# Altium schematic sheet Time_Clock_Colon_V1.0.0.SchDoc
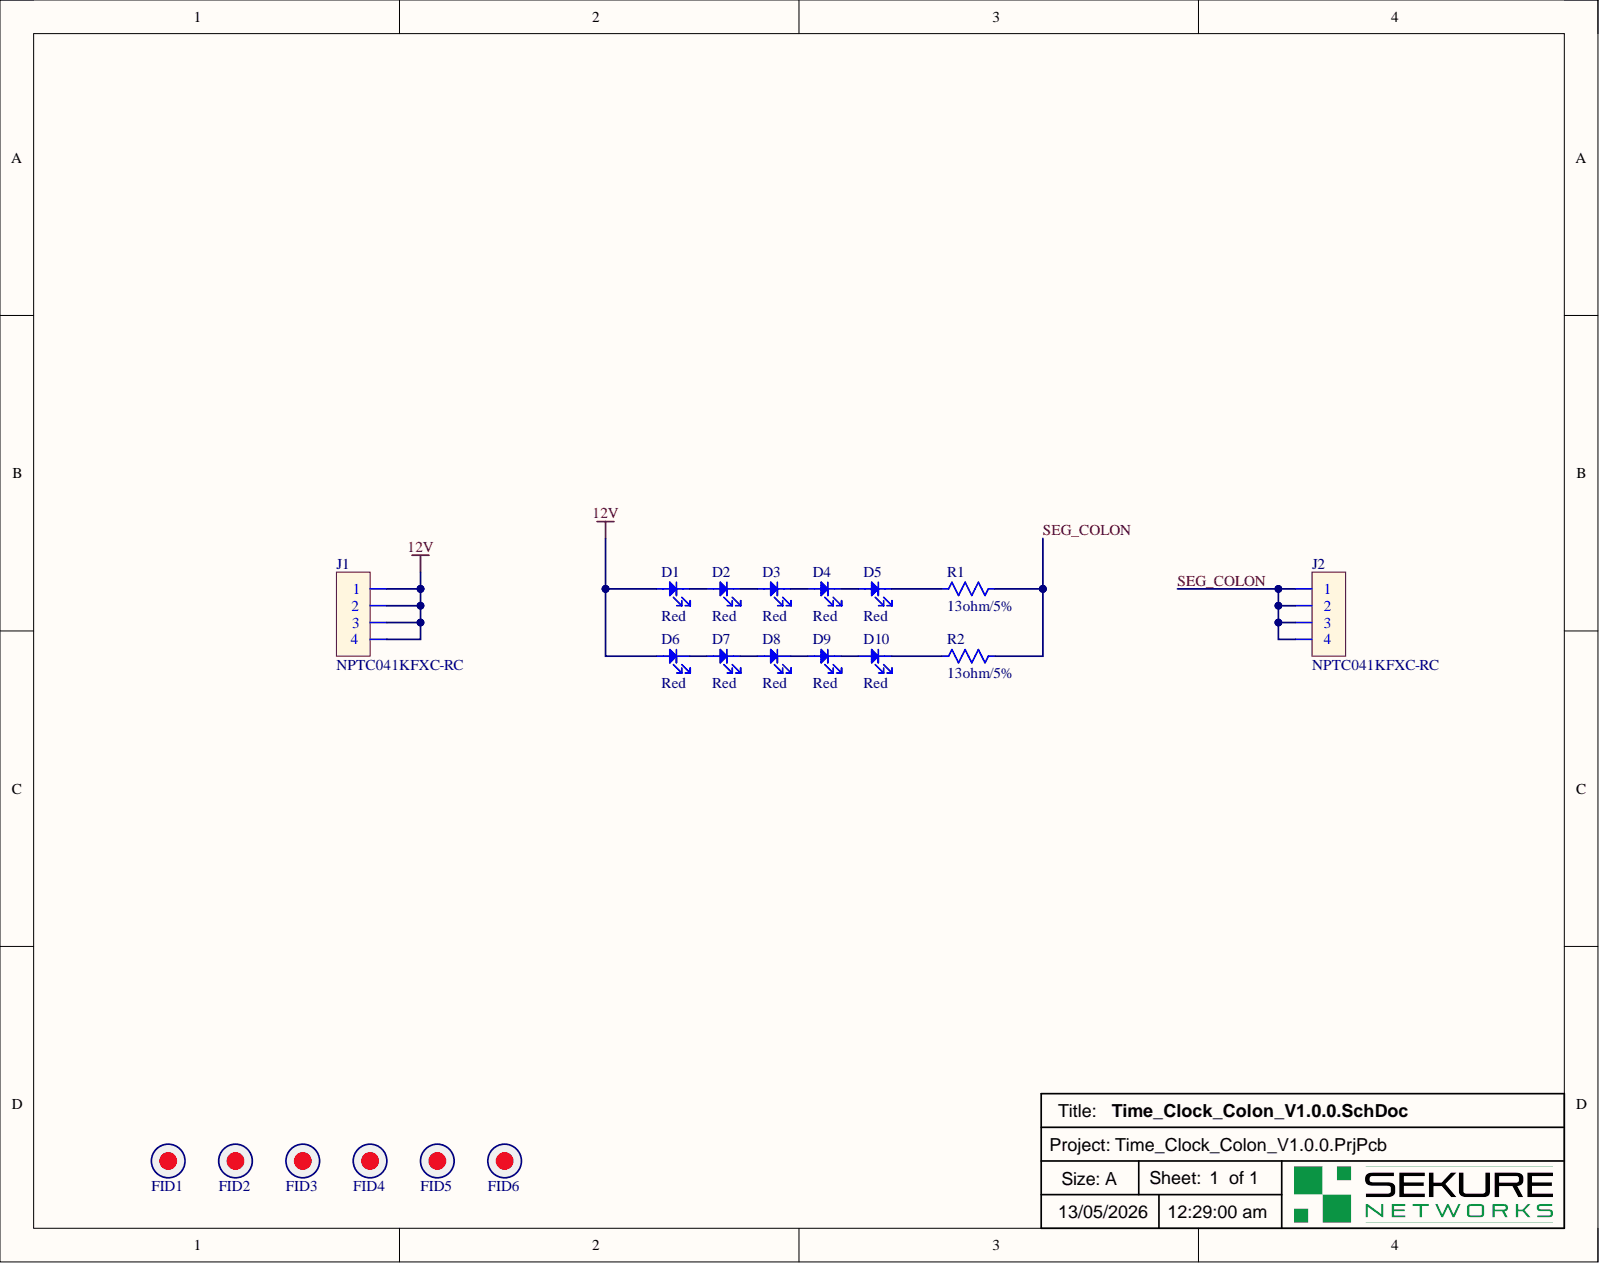
[diagram p0: Time_Clock_Colon_V1.0.0.SchDoc]

## Parts
D1  value Red  Color: Red  [1113 (2835 Metric)]  (hidden comment: LED)
D2  value Red  Color: Red  [1113 (2835 Metric)]  (hidden comment: LED)
D3  value Red  Color: Red  [1113 (2835 Metric)]  (hidden comment: LED)
D4  value Red  Color: Red  [1113 (2835 Metric)]  (hidden comment: LED)
D5  value Red  Color: Red  [1113 (2835 Metric)]  (hidden comment: LED)
D6  value Red  Color: Red  [1113 (2835 Metric)]  (hidden comment: LED)
D7  value Red  Color: Red  [1113 (2835 Metric)]  (hidden comment: LED)
D8  value Red  Color: Red  [1113 (2835 Metric)]  (hidden comment: LED)
D9  value Red  Color: Red  [1113 (2835 Metric)]  (hidden comment: LED)
D10  value Red  Color: Red  [1113 (2835 Metric)]  (hidden comment: LED)
FID1  Fiducal marks  [Fiducial mark]  (value not drawn)  (no BOM)
FID2  Fiducal marks  [Fiducial mark]  (value not drawn)  (no BOM)
FID3  Fiducal marks  [Fiducial mark]  (value not drawn)  (no BOM)
FID4  Fiducal marks  [Fiducial mark]  (value not drawn)  (no BOM)
FID5  Fiducal marks  [Fiducial mark]  (value not drawn)  (no BOM)
FID6  Fiducal marks  [Fiducial mark]  (value not drawn)  (no BOM)
J1  value NPTC041KFXC-RC  [NPTC041KFXC-RC]  (hidden comment: Connector)
J2  value NPTC041KFXC-RC  [NPTC041KFXC-RC]  (hidden comment: Connector)
R1  value 13ohm/5%  [RES 0805]  (hidden comment: Resistor)
R2  value 13ohm/5%  [RES 0805]  (hidden comment: Resistor)

## Nets
12V: D1.1 D6.1 J1.1 J1.2 J1.3 J1.4
SEG_COLON: J2.1 J2.2 J2.3 J2.4 R1.2 R2.2
NetD1_2: D1.2 D2.1
NetD2_2: D2.2 D3.1
NetD3_2: D3.2 D4.1
NetD4_2: D4.2 D5.1
NetD5_2: D5.2 R1.1
NetD6_2: D6.2 D7.1
NetD7_2: D7.2 D8.1
NetD8_2: D8.2 D9.1
NetD9_2: D9.2 D10.1
NetD10_2: D10.2 R2.1

Not connected: FID1 0; FID2 0; FID3 0; FID4 0; FID5 0; FID6 0

## Library data of the parts
D1-D10 = L128-RED1003500000: 2835 Color Line Red 17 Lumens 250 mA 2.1 V Surface Mount Mid Power LED; Manufacturer: Lumileds; Forward Current: 250mA; Forward Voltage: 2.5V; Number of Circuits: 1; Viewing Angle: 130°; Category: LEDs; Component Kind: Standard; ComponentLink1Description: https://datasheet.octopart.com:443/L128-RED1003500000-Lumileds-datasheet-180614725.pdf?src-supplier=IHS; ComponentLink1URL: https://datasheet.octopart.com:443/L128-RED1003500000-Lumileds-datasheet-180614725.pdf?src-supplier=IHS; ComponentLink2Description: https://datasheet.octopart.com:443/L128-RED1003500000-Lumileds-datasheet-158813306.pdf?src-supplier=ODG+(Origin+Data+Global); ComponentLink2URL: https://datasheet.octopart.com:443/L128-RED1003500000-Lumileds-datasheet-158813306.pdf?src-supplier=ODG+(Origin+Data+Global); ComponentLink3Description: https://datasheet.octopart.com:443/L128-RED1003500000-Philips-Lumileds-datasheet-145437216.pdf?src-supplier=Future+Electronics; ComponentLink3URL: https://datasheet.octopart.com:443/L128-RED1003500000-Philips-Lumileds-datasheet-145437216.pdf?src-supplier=Future+Electronics; ComponentLink4Description: https://datasheet.octopart.com:443/L128-RED1003500000-Philips-Lumileds-datasheet-138797548.pdf?src-supplier=Future+Electronics; ComponentLink4URL: https://datasheet.octopart.com:443/L128-RED1003500000-Philips-Lumileds-datasheet-138797548.pdf?src-supplier=Future+Electronics; ComponentLink5Description: https://datasheet.octopart.com:443/L128-RED1003500000-Philips-Lumileds-datasheet-139878679.pdf?src-supplier=Future+Electronics; ComponentLink5URL: https://datasheet.octopart.com:443/L128-RED1003500000-Philips-Lumileds-datasheet-139878679.pdf?src-supplier=Future+Electronics; ComponentLink6Description: https://datasheet.octopart.com:443/L128-RED1003500000-Philips-Lumileds-datasheet-127822332.pdf?src-supplier=Future+Electronics; ComponentLink6URL: https://datasheet.octopart.com:443/L128-RED1003500000-Philips-Lumileds-datasheet-127822332.pdf?src-supplier=Future+Electronics; ComponentLink7Description: https://datasheet.octopart.com:443/L128-RED1003500000-Philips-Lumileds-datasheet-120821803.pdf?src-supplier=Future+Electronics; ComponentLink7URL: https://datasheet.octopart.com:443/L128-RED1003500000-Philips-Lumileds-datasheet-120821803.pdf?src-supplier=Future+Electronics; ComponentLink8Description: https://datasheet.octopart.com:443/L128-RED1003500000-Philips-Lumileds-datasheet-130255201.pdf?src-supplier=Component+Distributors+Inc.; ComponentLink8URL: https://datasheet.octopart.com:443/L128-RED1003500000-Philips-Lumileds-datasheet-130255201.pdf?src-supplier=Component+Distributors+Inc.; ComponentLink9Description: http://sigma.octopart.com:80/reach_statement/NXP-Semiconductors-company-13.pdf?src-supplier=Compliance+Statements; ComponentLink9URL: http://sigma.octopart.com:80/reach_statement/NXP-Semiconductors-company-13.pdf?src-supplier=Compliance+Statements; ComponentLink10Description: http://sigma.octopart.com:80/rohs_statement/NXP-Semiconductors-company-12.pdf?src-supplier=Compliance+Statements; ComponentLink10URL: http://sigma.octopart.com:80/rohs_statement/NXP-Semiconductors-company-12.pdf?src-supplier=Compliance+Statements; Country of Origin: Mainland China; DigiKey: 1416-L128-RED1003500000CT-ND; Harmonized Tariff Schedule (HTS) Code: 8541.40.20.00; Height: 700µm; Introduction Date: 2018-10-31; Library Name: LEDs.SchLib; Lifecycle Status: Production; Max Operating Temperature: 105°C; Mounting Technology: Surface Mount; Number of Terminals: 2; P/N: L128-RED1003500000; Pricing: 1=0.53, 10=0.364, 100=0.2616, 500=0.21562, 1000=0.2005, 2000=0.18763 (USD); REACH SVHC: Yes; RoHS: Compliant; Schedule B: 8541402000, 8541402000|8541402000, 8541402000|8541402000|8541402000, 8541402000|8541402000|8541402000|8541402000; Stock: 52049; Supplier: DigiKey; Terminal Pitch: 2mm
FID1-FID6 = Fiducial mark: Fiducial mark mini  in top placement.; Number: 1; Library Name: Connectors.IntLib
J1 J2 = NPTC041KFXC-RC: Board Connector, 4 Contact(s), 1 Row(s), Female, Straight, 0.1 inch Pitch, Surface Mount Terminal, Locking; Manufacturer: Sullins; Connector Type: Header, Socket; Current Rating: 3A; Dielectric Material: Polyamide; Number of Positions: 4; Pitch: 2.54mm; Category: Card Edge Connectors; Color: Black; Component Kind: Standard; ComponentLink1Description: https://datasheet.octopart.com:443/NPTC041KFXC-RC-Sullins-datasheet-138810743.pdf?src-supplier=IHS; ComponentLink1URL: https://datasheet.octopart.com:443/NPTC041KFXC-RC-Sullins-datasheet-138810743.pdf?src-supplier=IHS; ComponentLink2Description: https://datasheet.octopart.com:443/NPTC041KFXC-RC-Sullins-datasheet-41308905.pdf?src-supplier=Future+Electronics; ComponentLink2URL: https://datasheet.octopart.com:443/NPTC041KFXC-RC-Sullins-datasheet-41308905.pdf?src-supplier=Future+Electronics; ComponentLink3Description: https://datasheet.octopart.com:443/NPTC041KFXC-RC-Sullins-datasheet-120059.pdf?src-supplier=DigiKey; ComponentLink3URL: https://datasheet.octopart.com:443/NPTC041KFXC-RC-Sullins-datasheet-120059.pdf?src-supplier=DigiKey; Contact Material: Bronze; Contact Plating: Tin; DigiKey: S5596-ND; Export Control Classification Number (ECCN) Code: EAR99; Gender: Female; Harmonized Tariff Schedule (HTS) Code: 8536.69.40.40; Height: 7.1mm; Housing Color: Black; Housing Material: Nylon; Introduction Date: 2008-05-14; Lead Free: Lead Free; Length: 10.2mm; Library Name: Connectors.IntLib; Lifecycle Status: Production; Mounting Technology: Surface Mount; Number of Rows: 2; P/N: NPTC041KFXC-RC; Packaging: Bulk; Pricing: 50=0.39, 150=0.38, 400=0.37, 1000=0.365, 2500=0.35 (USD); REACH SVHC: Yes; RoHS: Compliant; Supplier: DigiKey; Terminal Pitch: 5.08mm; Termination: Solder; Width: 2.5mm
R1 R2 = ERJ-P06J130V: Res Thick Film 0805 13 Ohm 5% 0.25W ±300ppm/°C Molded SMD Punched Carrier T/R; Manufacturer: Panasonic; Max Power Dissipation: 250mW; Power Rating: 500mW; Voltage Rating: 150V; Case Code (Imperial): 0805; Case/Package: 0805; Category: Chip SMD Resistors; Component Kind: Standard; ComponentLink1Description: https://datasheet.octopart.com:443/ERJ-P06J130V-Panasonic-datasheet-142522929.pdf?src-supplier=Master+Electronics; ComponentLink1URL: https://datasheet.octopart.com:443/ERJ-P06J130V-Panasonic-datasheet-142522929.pdf?src-supplier=Master+Electronics; ComponentLink2Description: https://datasheet.octopart.com:443/ERJ-P06J130V-Panasonic-datasheet-118681.pdf?src-supplier=DigiKey; ComponentLink2URL: https://datasheet.octopart.com:443/ERJ-P06J130V-Panasonic-datasheet-118681.pdf?src-supplier=DigiKey; ComponentLink3Description: https://datasheet.octopart.com:443/ERJ-P06J130V-Panasonic-datasheet-16484091.pdf?src-supplier=Electro+Sonic; ComponentLink3URL: https://datasheet.octopart.com:443/ERJ-P06J130V-Panasonic-datasheet-16484091.pdf?src-supplier=Electro+Sonic; ComponentLink4Description: https://datasheet.octopart.com:443/ERJ-P06J130V-Panasonic-datasheet-13272697.pdf?src-supplier=Electro+Sonic; ComponentLink4URL: https://datasheet.octopart.com:443/ERJ-P06J130V-Panasonic-datasheet-13272697.pdf?src-supplier=Electro+Sonic; Composition: Thick Film; Contact Plating: Tin; DigiKey: P13ADCT-ND; Height: 711.2µm; Lead Free: Contains Lead; Length: 2.0066mm; Library Name: Resistors.IntLib; Max Operating Temperature: 155°C; Min Operating Temperature: -55°C; Mounting Technology: Surface Mount; Number of Terminations: 2; P/N: ERJ-P06J130V; Pricing: 1=0.12, 10=0.058, 25=0.0448, 50=0.0372, 100=0.0313, 250=0.0254, 500=0.02206, 1000=0.01942 (USD); Radiation Hardening: No; RoHS: Compliant; Schedule B: 8533210045, 8533210045|8533210045, 8533210045|8533210045|8533210045, 8533210045|8533210045|8533210045|8533210045; Stock: 27789; Supplier: DigiKey; Temperature Coefficient: 300ppm/°C; Termination: SMD/SMT; Tolerance: 5%; Voltage Rating (DC): 150V; Width: 1.2mm
